# Altium schematic sheet BuckBoost.SchDoc(Designator)
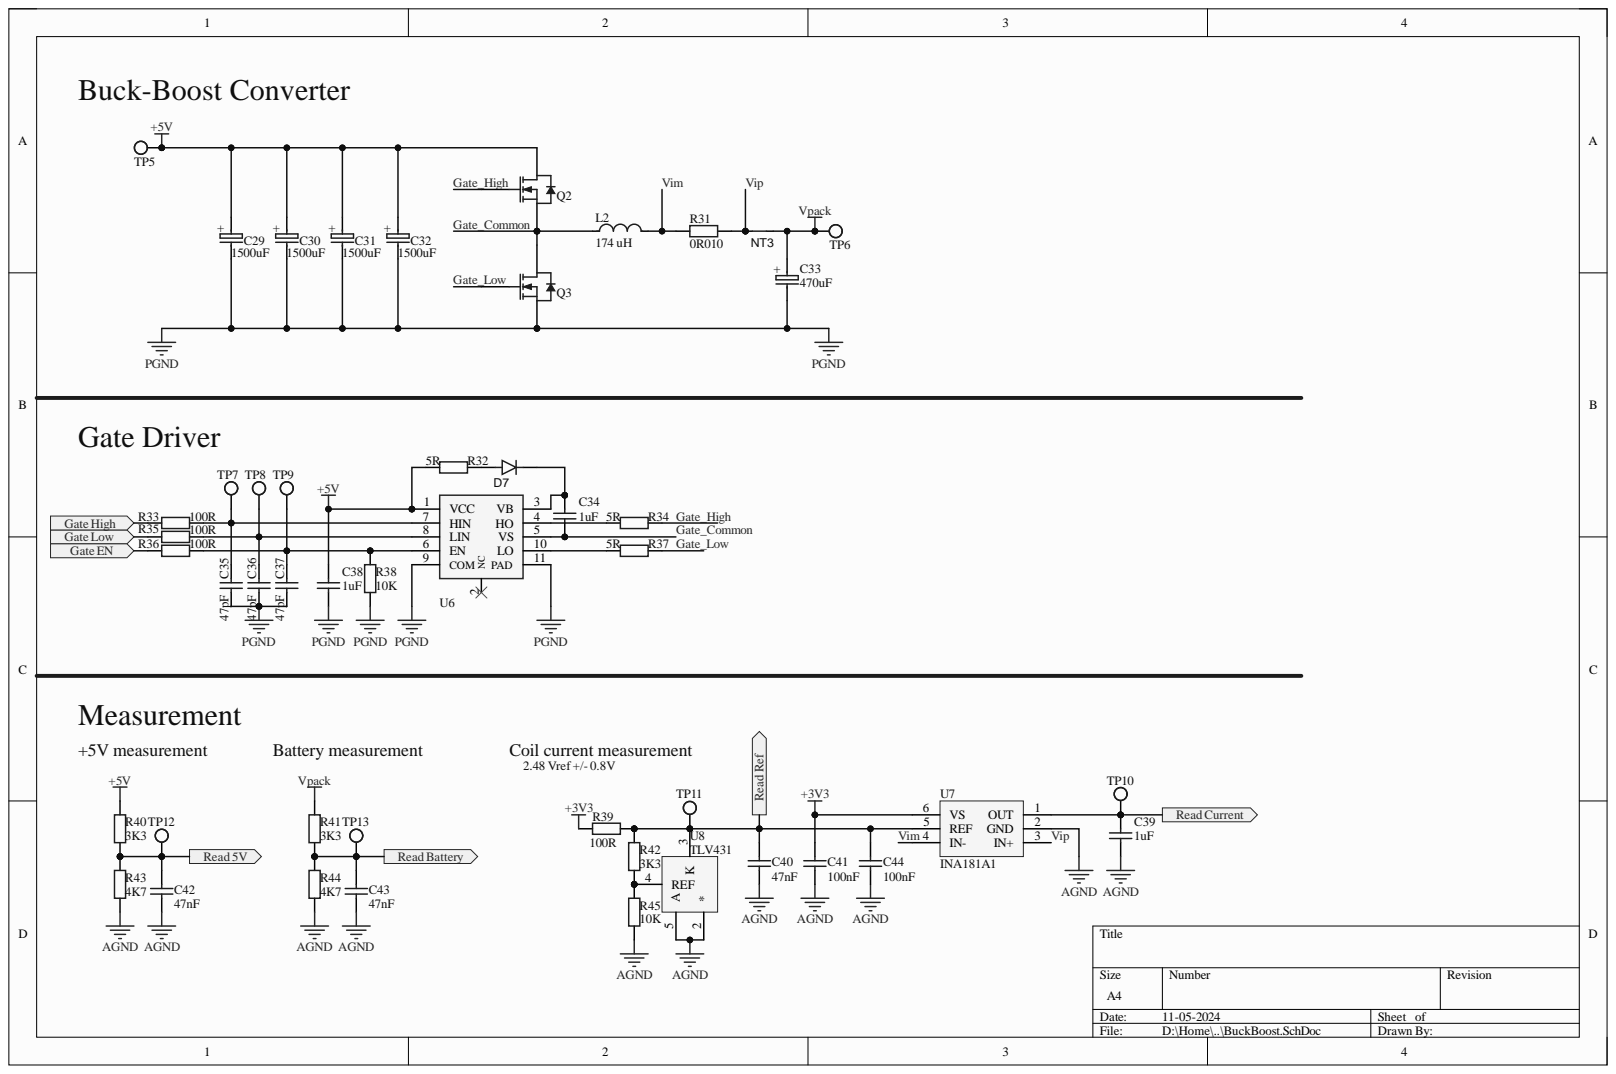
[diagram p0: BuckBoost.SchDoc]

## Parts
C29  value 1500uF  [Aluminium Capacitor 10x12.5]  (hidden comment: RNU0J152MDN1PH)
C30  value 1500uF  [Aluminium Capacitor 10x12.5]  (hidden comment: RNU0J152MDN1PH)
C31  value 1500uF  [Aluminium Capacitor 10x12.5]  (hidden comment: RNU0J152MDN1PH)
C32  value 1500uF  [Aluminium Capacitor 10x12.5]  (hidden comment: RNU0J152MDN1PH)
C33  470uF  [Aluminium Capacitor 10x12.5]
C34  1uF  [CAP0603]
C35  47pF  [CAP0603]
C36  47pF  [CAP0603]
C37  47pF  [CAP0603]
C38  1uF  [CAP0603]
C39  1uF  [CAP0603]
C40  47nF  [CAP0603]
C41  100nF  [CAP0603]
C42  47nF  [CAP0603]
C43  47nF  [CAP0603]
C44  100nF  [CAP0603]
D7  Value  [SMB]  (value not drawn)
L2  value 174 uH  [COIL_HANDMADE]  (hidden comment: Inductor)
NT3  NetTie_1-1  [NETTIE_1.85MM]  (value not drawn)
Q2  N-MOSFET  [SOT-669-5]  (value not drawn)
Q3  N-MOSFET  [SOT-669-5]  (value not drawn)
R31  0R010  [RES1206_KELVIN]
R32  5R  [RES0603]
R33  100R  [RES0603]
R34  5R  [RES0603]
R35  100R  [RES0603]
R36  100R  [RES0603]
R37  5R  [RES0603]
R38  10K  [RES0603]
R39  100R  [RES0603]
R40  3K3  [RES0603]
R41  3K3  [RES0603]
R42  3K3  [RES0603]
R43  4K7  [RES0603]
R44  4K7  [RES0603]
R45  10K  [RES0603]
TP5  Test Point  [TP-1.5HOLE]  (value not drawn)
TP6  Test Point  [TP-1.5HOLE]  (value not drawn)
TP7  Test Point  [TP-1.5HOLE]  (value not drawn)
TP8  Test Point  [TP-1.5HOLE]  (value not drawn)
TP9  Test Point  [TP-1.5HOLE]  (value not drawn)
TP10  Test Point  [TP-1.5HOLE]  (value not drawn)
TP11  Test Point  [TP-1.5HOLE]  (value not drawn)
TP12  Test Point  [TP-1.5HOLE]  (value not drawn)
TP13  Test Point  [TP-1.5HOLE]  (value not drawn)
U6  DGD05473FN-7  [V-DFN3030-10]  (value not drawn)
U7  INA181A1  [SOT23-6]
U8  TLV431  [SOT23-5]

## Ports
Gate EN, Gate High, Gate Low, Read 5V, Read Battery, Read Current, Read Ref

## Nets
+3V3: C41.1 R39.1 U7.6(VS)
+5V: C29.2 C30.2 C31.2 C32.2 C38.2 Q2.3 R32.1 R40.2 TP5.1 U6.1(VCC)
AGND: C39.1 C40.1 C41.2 C42.1 C43.1 C44.2 R43.1 R44.1 R45.1 U7.2(GND) U8.2(*) U8.5(A)
PGND: C29.1 C30.1 C31.1 C32.1 C33.1 C35.1 C36.1 C37.1 C38.1 Q3.2 R38.2 U6.9(COM) U6.11(PAD)
Vpack: C33.2 NT3.2 R41.2 TP6.1
Gate EN: R36.1 ; port Gate EN
Gate High: R33.1 ; port Gate High
Gate Low: R35.1 ; port Gate Low
Gate_Common: C34.1 L2.1 Q2.2 Q3.3 U6.5(VS)
Gate_High: Q2.1 R34.2
Gate_Low: Q3.1 R37.2
Read 5V: C42.2 R40.1 R43.2 TP12.1 ; port Read 5V
Read Battery: C43.2 R41.1 R44.2 TP13.1 ; port Read Battery
Read Current: C39.2 TP10.1 U7.1(OUT) ; port Read Current
Read Ref: C40.2 C44.1 R39.2 R42.2 TP11.1 U7.5(REF) U8.3(K) ; port Read Ref
Vim: L2.2 R31.1 U7.4(IN-)
Vip: NT3.1 R31.2 U7.3(IN+)
NetC34_2: C34.2 D7.1 U6.3(VB)
NetC35_2: C35.2 R33.2 TP7.1 U6.7(HIN)
NetC36_2: C36.2 R35.2 TP8.1 U6.8(LIN)
NetC37_2: C37.2 R36.2 R38.1 TP9.1 U6.6(EN)
NetD7_2: D7.2 R32.2
NetR34_1: R34.1 U6.4(HO)
NetR37_1: R37.1 U6.10(LO)
NetR42_1: R42.1 R45.2 U8.4(REF)
NetU6_2: U6.2(NC)

## Library data of the parts
C29-C32 = RNU0J152MDN1PH: Aluminum Electrolytic Capacitor, Polarized, Aluminum (solid Polymer), 6.3V, 20% +Tol, 20% -Tol, 1500uF, Through Hole Mount; Manufacturer: Nichicon; Manufacturer Series: NU; Capacitor Type: Aluminium; ESR (Equivalent Series Resistance): 7mR; Leakage Current: 1.89mA; Ripple Current: 6.1A; Tan Delta: 0.08; Category: Polymer Capacitors; Component Kind: Standard; ComponentLink1Description: https://datasheet.ciiva.com:443/pdfs/VipMasterIC/IC/NICH/NICH-S-A0001375683/NICH-S-A0001375683-1.pdf?src-supplier=IHS+Markit; ComponentLink1URL: https://datasheet.ciiva.com:443/pdfs/VipMasterIC/IC/NICH/NICH-S-A0001375683/NICH-S-A0001375683-1.pdf?src-supplier=IHS+Markit; ComponentLink2Description: https://datasheet.ciiva.com/6316/2013fpcap-catalog-nu-6316953.pdf?src-supplier=Digikey; ComponentLink2URL: https://datasheet.ciiva.com/6316/2013fpcap-catalog-nu-6316953.pdf?src-supplier=Digikey; ComponentLink3Description: https://datasheet.ciiva.com/6316/2013fpcap-catalog-nu-6316955.pdf?src-supplier=Digikey; ComponentLink3URL: https://datasheet.ciiva.com/6316/2013fpcap-catalog-nu-6316955.pdf?src-supplier=Digikey; Diameter: 10mm; Dielectric Material: Aluminium; JESD-609 Code: e3; Length: 12.5mm; Manufacturer Part Number: RNU0J152MDN1PH; Max Operating Temperature: 105°C; Min Operating Temperature: -55°C; Mounting Technology: Through Hole; Package Shape: Cylindrical; Package Style: RadialMeter; Packaging: Tape and Reel; Pins: 2; Polarity: Polarized; Pricing: 500=0.37, 1000=0.36, 2000=0.35, 2500=0.35, 7500=0.335 (USD); Rated DC Voltage (URdc): 6.3V; Reach SVHC Compliant: Yes; RoHS: Yes; RoHS Compliant: Yes; Series: NU; Stock: 1000; Supplier: Future Electronics; Supplier Part Number: 4133684; Terminal Finish: Tin; Terminal Pitch: 5mm; Termination: Wire; Tolerance  (fill in as +-): 20%; Tolerance (fill in as +-): 20%; Library Name: UPS_Schlib.SchLib
C33 = RR50J471MDN1KX: Aluminum Electrolytic Capacitor, Aluminum (solid Polymer); Manufacturer: Nichicon; Capacitor Type: Aluminium; Category: Polymer Capacitors; Component Kind: Standard; ComponentLink1Description: https://datasheet.ciiva.com:443/pdfs/VipMasterIC/IC/NICH/NICH-S-A0003527494/NICH-S-A0003527494-1.pdf?src-supplier=IHS+Markit; ComponentLink1URL: https://datasheet.ciiva.com:443/pdfs/VipMasterIC/IC/NICH/NICH-S-A0003527494/NICH-S-A0003527494-1.pdf?src-supplier=IHS+Markit; ComponentLink2Description: http://www.mouser.com/ds/2/293/e-rr5-875910.pdf?src-supplier=Mouser; ComponentLink2URL: http://www.mouser.com/ds/2/293/e-rr5-875910.pdf?src-supplier=Mouser; ComponentLink3Description: http://nichicon-us.com/english/products/pdfs/e-rr5.pdf?src-supplier=Digikey; ComponentLink3URL: http://nichicon-us.com/english/products/pdfs/e-rr5.pdf?src-supplier=Digikey; Dielectric Material: Aluminium; Manufacturer Part Number: RR50J471MDN1KX; Pins: 2; Pricing: 1=0.65, 10=0.409, 50=0.409, 100=0.334, 1000=0.265, 10000=0.265 (USD); Reach SVHC Compliant: Yes; RoHS: Yes; RoHS Compliant: Yes; Stock: 272; Supplier: Mouser; Supplier Part Number: 647-RR50J471MDN1KX; Value: 470uF; Library Name: UPS_Schlib.SchLib
C34 C38 C39 = 1uF: Capacitor; Component Kind: Standard; Value: 1uF; Library Name: UPS_Schlib.SchLib
C35-C37 = 47pF: Ceramic Capacitor, Multilayer, Ceramic, 100V, 5% +Tol, 5% -Tol, C0G, 30ppm/Cel TC, 0.000047uF, Surface Mount, 0603; Manufacturer: KEMET; Manufacturer Part Number: C0603C470J1GACTU; Multilayer: Yes; Capacitor Type: Ceramic; Case/Package: 0603; Category: Ceramic Capacitors; Component Kind: Standard; ComponentLink1Description: https://datasheet.ciiva.com:443/pdfs/VipMasterIC/IC/KEME/KEME-S-A0005605168/KEME-S-A0005605168-1.pdf?src-supplier=IHS+Markit; ComponentLink1URL: https://datasheet.ciiva.com:443/pdfs/VipMasterIC/IC/KEME/KEME-S-A0005605168/KEME-S-A0005605168-1.pdf?src-supplier=IHS+Markit; ComponentLink2Description: https://datasheet.ciiva.com/28668/1789732-28668693.pdf?src-supplier=Element14; ComponentLink2URL: https://datasheet.ciiva.com/28668/1789732-28668693.pdf?src-supplier=Element14; ComponentLink3Description: https://datasheet.ciiva.com/18193/getdatasheetpartid-544691-18193046.pdf?src-supplier=Verical; ComponentLink3URL: https://datasheet.ciiva.com/18193/getdatasheetpartid-544691-18193046.pdf?src-supplier=Verical; ComponentLink4Description: https://datasheet.ciiva.com/18101/getdatasheetpartid-38001-18101098.pdf?src-supplier=Verical; ComponentLink4URL: https://datasheet.ciiva.com/18101/getdatasheetpartid-38001-18101098.pdf?src-supplier=Verical; Dielectric Material: Ceramic; Height: 0.8mm; JESD-609 Code: e3; Length: 1.6mm; Max Operating Temperature: 125°C; Min Operating Temperature: -55°C; Mounting Technology: Surface Mount; Package Shape: Rectangular; Package Style: SMTMeter; Packaging: Tape and Reel; Pins: 2; Pricing: 1=0.1, 10=0.026, 50=0.026, 100=0.016, 1000=0.012, 10000=0.007 (USD); Rated DC Voltage (URdc): 100V; Reach SVHC Compliant: Yes; RoHS: Yes; RoHS Compliant: Yes; Stock: 43381; Supplier: Mouser; Supplier Part Number: 80-C0603C470J1G; Temperature Characteristics Code: C0G; Terminal Finish: Nickel; Termination: Wraparound; Tolerance  (fill in as +-): 5%; Tolerance (fill in as +-): 5%; Value: 47pF; Width: 0.8mm; Library Name: UPS_Schlib.SchLib
C40 C42 C43 = 47nF: Capacitor; Component Kind: Standard; Value: 47nF; Library Name: UPS_Schlib.SchLib
C41 C44 = 100nF: Capacitor; Component Kind: Standard; Value: 100nF; Library Name: UPS_Schlib.SchLib
D7 = VS-MBRS130L-M3/5BT: Rectifier Diode, Schottky, 1 Phase, 1 Element, 30V V(RRM), Silicon; Manufacturer: Vishay Semiconductors; Additional Feature: FREE WHEELING DIODE; If: 1 A; Number of Elements: 1; Package Body Material: Plastic; Vf: 300 mV; Category: Rectifier Diodes; Component Kind: Standard; ComponentLink1Description: https://datasheet.ciiva.com:443/pdfs/VipMasterIC/IC/VISH/VISH-S-A0001785244/VISH-S-A0001785244-1.pdf?src-supplier=IHS+Markit; ComponentLink1URL: https://datasheet.ciiva.com:443/pdfs/VipMasterIC/IC/VISH/VISH-S-A0001785244/VISH-S-A0001785244-1.pdf?src-supplier=IHS+Markit; ComponentLink2Description: http://www.mouser.com/ds/2/427/vsmbrs130lm3-552915.pdf?src-supplier=Mouser; ComponentLink2URL: http://www.mouser.com/ds/2/427/vsmbrs130lm3-552915.pdf?src-supplier=Mouser; ComponentLink3Description: http://www.vishay.com/docs/95745/vsmbrs130lm3.pdf?src-supplier=Digikey; ComponentLink3URL: http://www.vishay.com/docs/95745/vsmbrs130lm3.pdf?src-supplier=Digikey; ComponentLink4Description: https://datasheet.octopart.com/VS-MBRS130L-M3-5BT-Vishay-datasheet-41960962.pdf?src-supplier=Future+Electronics; ComponentLink4URL: https://datasheet.octopart.com/VS-MBRS130L-M3-5BT-Vishay-datasheet-41960962.pdf?src-supplier=Future+Electronics; Diode Element Material: SILICON; Diode Type: RECTIFIER; JESD-30 Code: R-PDSO-C2; JESD-609 Code: e3; Manufacturer Part Number: VS-MBRS130L-M3/5BT; Max Operating Temperature: 125°C; Min Operating Temperature: -55°C; Moisture Sensitivity Level: 1; Package Shape: Rectangular; Package Style: SMALL OUTLINEMeter; Pins: 2; Pricing: 1=0.26, 10=0.211, 50=0.211, 100=0.15, 1000=0.105, 10000=0.08 (USD); Reach SVHC Compliant: No; Rep Pk Reverse Voltage-Max: 30V; RoHS: Yes; RoHS Compliant: Yes; Stock: 107658; Supplier: Mouser; Supplier Part Number: 78-VS-MBRS130L-M35BT; Surface Mount: Yes; Technology: SCHOTTKY; Terminal Finish: Tin; Terminal Form: C BEND; Terminal Position: DUAL; Vrrm: 30 V; Library Name: UPS_Schlib.SchLib
L2 = Inductor: Hand made inductance; Component Kind: Standard; Library Name: UPS_Schlib.SchLib
NT3 = NetTie_1-1: NetTie 1 -> 1; ID: 22; Inventory_Category: Other; Value: NetTie 1-1 0.2mm; Component Kind: Net Tie; Part Class: NetTie; Technology: SMT; Library Name: UPS_Schlib.SchLib
Q2 Q3 = PSMN1R2-25YLDX: Power Field-Effect Transistor, 100A I(D), 25V, 0.00169ohm, 1-Element, N-Channel, Silicon, Metal-oxide Semiconductor FET, MO-235; Manufacturer: Nexperia; Additional Feature: HIGH RELIABILITY; Avalanche Energy Rating (Eas): 1293mJ; Case Connection: DRAIN; Drain-source On Resistance-Max: 1.69mR; Number of Elements: 1; Operating Mode: ENHANCEMENT MODE; Package Body Material: Plastic; Pulsed Drain Current-Max (IDM): 1.163kA; Reference Standard: IEC-60134; Transistor Application: SWITCHING; Category: Transistors; Component Kind: Standard; ComponentLink1Description: https://datasheet.ciiva.com:443/pdfs/VipMasterIC/IC/NEXP/NEXP-S-A0003107917/NEXP-S-A0003107917-1.pdf?src-supplier=IHS+Markit; ComponentLink1URL: https://datasheet.ciiva.com:443/pdfs/VipMasterIC/IC/NEXP/NEXP-S-A0003107917/NEXP-S-A0003107917-1.pdf?src-supplier=IHS+Markit; Drain Current-Max (ID): 100A; DS Breakdown Voltage-Min: 25V; FET Technology: METAL-OXIDE SEMICONDUCTOR; JEDEC-95 Code: MO-235; Manufacturer Part Number: PSMN1R2-25YLDX; Package Shape: Rectangular; Package Style: SMALL OUTLINEMeter; Pins: 4; Polarity/Channel Type: N-CHANNEL; Pricing: 1=0.7, 10=0.694, 50=0.694, 100=0.663, 1000=0.483, 10000=0.481 (USD); Reach SVHC Compliant: No; RoHS: Yes; RoHS Compliant: Yes; Stock: 20971; Supplier: Mouser; Supplier Part Number: 771-PSMN1R2-25YLDX; Surface Mount: Yes; Terminal Form: Gull Wing; Terminal Position: SINGLE; Transistor Element Material: SILICON; Library Name: UPS_Schlib.SchLib
R31 = 0R010: Resistor Current Sense Metal Foil 1206 10m Ohm 1% 1W ±50ppm/°C T/R; Manufacturer: Eaton; Manufacturer Part Number: MFSA1206R0050FCM; Category: Chip SMD Resistors; Component Kind: Standard; Pricing: 1=0.21, 10=0.173, 50=0.173, 100=0.128, 1000=0.096, 10000=0.062 (USD); RoHS: No; Stock: 9199; Supplier Part Number: 504-MFSA1206R0050FCM; Temperature Coefficient: 50ppm/°C; Value: 0R010; Library Name: UPS_Schlib.SchLib
R32 R34 R37 = 5R: Resistor; Component Kind: Standard; Value: 5R; Library Name: UPS_Schlib.SchLib
R33 R35 R36 R39 = 100R: Resistor; Component Kind: Standard; Value: 100R; Library Name: UPS_Schlib.SchLib
R38 R45 = 10K: Resistor; Component Kind: Standard; Value: 10K; Library Name: UPS_Schlib.SchLib
R40-R42 = 3K3: Fixed Resistor, Metal Glaze/thick Film, 0.1W, 3300ohm, 75V, 1% +/-Tol, 150ppm/Cel, Surface Mount, 0603; Manufacturer: Yageo; Manufacturer Part Number: RC0603FR-073K3P; Additional Feature: LASER TRIMMABLE; Rated Temperature: 70°C; Temperature Coefficient: 150ppm/°C; Case/Package: 0603; Category: Chip SMD Resistors; Component Kind: Standard; ComponentLink1Description: https://datasheet.ciiva.com:443/pdfs/VipMasterIC/IC/YAGO/YAGO-S-A0006248068/YAGO-S-A0006248068-1.pdf?src-supplier=IHS+Markit; ComponentLink1URL: https://datasheet.ciiva.com:443/pdfs/VipMasterIC/IC/YAGO/YAGO-S-A0006248068/YAGO-S-A0006248068-1.pdf?src-supplier=IHS+Markit; ComponentLink2Description: http://www.yageo.com.tw/exep/pages/download/literatures/PYu-R_INT-thick_7.pdf?src-supplier=Digikey; ComponentLink2URL: http://www.yageo.com.tw/exep/pages/download/literatures/PYu-R_INT-thick_7.pdf?src-supplier=Digikey; ComponentLink3Description: http://www.yageo.com/NewPortal/yageodocoutput?fileName=/pdf/R-Chip/PYu-RC_51_RoHS_P_0.pdf&src-supplier=Digikey; ComponentLink3URL: http://www.yageo.com/NewPortal/yageodocoutput?fileName=/pdf/R-Chip/PYu-RC_51_RoHS_P_0.pdf&src-supplier=Digikey; JESD-609 Code: e3; Max Operating Temperature: 155°C; Min Operating Temperature: -55°C; Mounting Technology: Surface Mount; Package Shape: Rectangular; Packaging: Tape and Reel; Pins: 2; Pricing: 1=0.1, 10=0.017, 50=0.017, 100=0.01, 1000=0.008, 10000=0.004 (USD); Reach SVHC Compliant: Yes; Resistor Type: FIXED RESISTOR; RoHS: Yes; RoHS Compliant: Yes; Stock: 14148; Supplier Part Number: 603-RC0603FR-073K3P; Technology: METAL GLAZE/THICK FILM; Terminal Finish: Nickel; Termination: Wraparound; Value: 3K3; Working Voltage: 75V; Library Name: UPS_Schlib.SchLib
R43 R44 = 4K7: Resistor; Component Kind: Standard; Value: 4K7; Library Name: UPS_Schlib.SchLib
U6 = DGD05473FN-7: HIGH FREQUENCY HIGH-SIDE AND LOW-SIDE GATE DRIVER; Manufacturer_Name: Diodes Incorporated; Arrow Part Number: DGD05473FN-7; Arrow Price/Stock: https://www.arrow.com/en/products/dgd05473fn-7/diodes-incorporated?region=nac; Component Kind: Standard; Datasheet Link: https://www.diodes.com/assets/Datasheets/DGD05473.pdf; Height: 0.8mm; Manufacturer_Part_Number: DGD05473FN-7; Mouser Part Number: 621-DGD05473FN-7; Mouser Price/Stock: https://www.mouser.co.uk/ProductDetail/Diodes-Incorporated/DGD05473FN-7?qs=W0yvOO0ixfECV6JdMBa%2F6g%3D%3D; Library Name: UPS_Schlib.SchLib
U7 = INA181A1: Operational Amplifier, 1 Func, 500uV Offset-Max, PDSO6; Manufacturer: Texas Instruments; Manufacturer Part Number: INA181A1IDBVR; Average Bias Current-Max (IIB): 75uA; Channels: 1; Common-mode Reject Ratio-Nom: 100dB; Nominal Supply Voltage: 5V; Package Body Material: Plastic; Slew Rate: 2V/µs; Supply Voltage Limit-Max: 5.5V; Unity Gain BW-Nom: 350kHz; Amplifier Type: OPERATIONAL AMPLIFIER; Case/Package: SOP; Category: Amplifiers - Op Amps, Buffer, Instrumentation; Component Kind: Standard; ComponentLink1Description: https://www.ti.com:443/lit/ds/symlink/ina181.pdf?src-supplier=IHS+Markit; ComponentLink1URL: https://www.ti.com:443/lit/ds/symlink/ina181.pdf?src-supplier=IHS+Markit; ComponentLink2Description: http://www.ti.com/lit/ds/symlink/ina181.pdf?src-supplier=Digikey; ComponentLink2URL: http://www.ti.com/lit/ds/symlink/ina181.pdf?src-supplier=Digikey; Input Offset Voltage-Max: 500uV; JESD-30 Code: R-PDSO-G6; JESD-609 Code: e3; Max Operating Temperature: 125°C; Min Operating Temperature: -40°C; Moisture Sensitivity Level: 1; Package Shape: Rectangular; Package Style: SMALL OUTLINEMeter; Peak Reflow Temperature (Cel): 260°C; Pins: 6; Pricing: 1=0.43, 10=0.256, 50=0.256, 100=0.198, 1000=0.169, 10000=0.147 (USD); Reach SVHC Compliant: Yes; RoHS: Yes; RoHS Compliant: Yes; Stock: 103029; Supplier: Mouser; Supplier Part Number: 595-INA181A1IDBVR; Surface Mount: Yes; Temperature Grade: AUTOMOTIVE; Terminal Finish: Tin; Terminal Form: Gull Wing; Terminal Position: DUAL; Library Name: UPS_Schlib.SchLib
U8 = TLV431: Three Terminal Voltage Reference, 1 Output, 1.24V, Trim/Adjustable, BIPolar, PDSO5; Manufacturer: Texas Instruments; Manufacturer Part Number: TLV431CDBVR; Channels: 1; Max Output current: 10mA; Max Output Voltage: 1.258V; Max Supply Voltage: 6V; Min Output Voltage: 1.222V; Package Body Material: Plastic; Package Equivalence Code: TSOP5/6,.11,37; Qualification Status: Not Qualified; Temp Coef of Voltage-Max: 138.249ppm/°C; Trim/Adjustable Output: Yes; Case/Package: LSSOP; Category: Voltage References; Component Kind: Standard; ComponentLink1Description: https://www.ti.com:443/lit/ds/symlink/tlv431.pdf?src-supplier=IHS+Markit; ComponentLink1URL: https://www.ti.com:443/lit/ds/symlink/tlv431.pdf?src-supplier=IHS+Markit; ComponentLink2Description: https://datasheet.ciiva.com/4065/29560336s9640988n7713-4065870.pdf?src-supplier=Arrow+Electronics; ComponentLink2URL: https://datasheet.ciiva.com/4065/29560336s9640988n7713-4065870.pdf?src-supplier=Arrow+Electronics; ComponentLink3Description: https://datasheet.ciiva.com/2006/tlv431a-2006342.pdf?src-supplier=Digikey; ComponentLink3URL: https://datasheet.ciiva.com/2006/tlv431a-2006342.pdf?src-supplier=Digikey; ComponentLink4Description: https://datasheet.ciiva.com/2006/tlv431a-2006343.pdf?src-supplier=Digikey; ComponentLink4URL: https://datasheet.ciiva.com/2006/tlv431a-2006343.pdf?src-supplier=Digikey; ComponentLink5Description: https://datasheet.ciiva.com/2006/tlv431a-2006344.pdf?src-supplier=Digikey; ComponentLink5URL: https://datasheet.ciiva.com/2006/tlv431a-2006344.pdf?src-supplier=Digikey; Height - Seated (Max): 1.45mm; JESD-30 Code: R-PDSO-G5; JESD-609 Code: e4; Length: 2.9mm; Max Operating Temperature: 70°C; Min Operating Temperature: 0°C; Min Supply Voltage: 1.24V; Moisture Sensitivity Level: 1; Nominal Output Voltage: 1.24V; Number of Outputs: 1; Package Shape: Rectangular; Package Style: SMALL OUTLINE, LOW PROFILE, SHRINK PITCHMeter; Peak Reflow Temperature (Cel): 260°C; Pins: 5; Pricing: 1=0.34, 10=0.274, 50=0.274, 100=0.203, 1000=0.138, 10000=0.137 (USD); Reach SVHC Compliant: Yes; RoHS: Yes; RoHS Compliant: Yes; Stock: 5017; Supplier: Mouser; Supplier Part Number: 595-TLV431CDBVR; Surface Mount: Yes; Technology: BIPOLAR; Temperature Grade: COMMERCIAL; Terminal Finish: Nickel; Terminal Form: Gull Wing; Terminal Pitch: 0.95mm; Terminal Position: DUAL; Throw Configuration: THREE TERMINAL VOLTAGE REFERENCE; Width: 1.6mm; Library Name: UPS_Schlib.SchLib
TP5-TP13 = Test Point: Component Kind: Standard; Description: Test Point; Library Name: UPS_Schlib.SchLib
